# Altium schematic sheet 01_FPGA_FlashMem.SchDoc
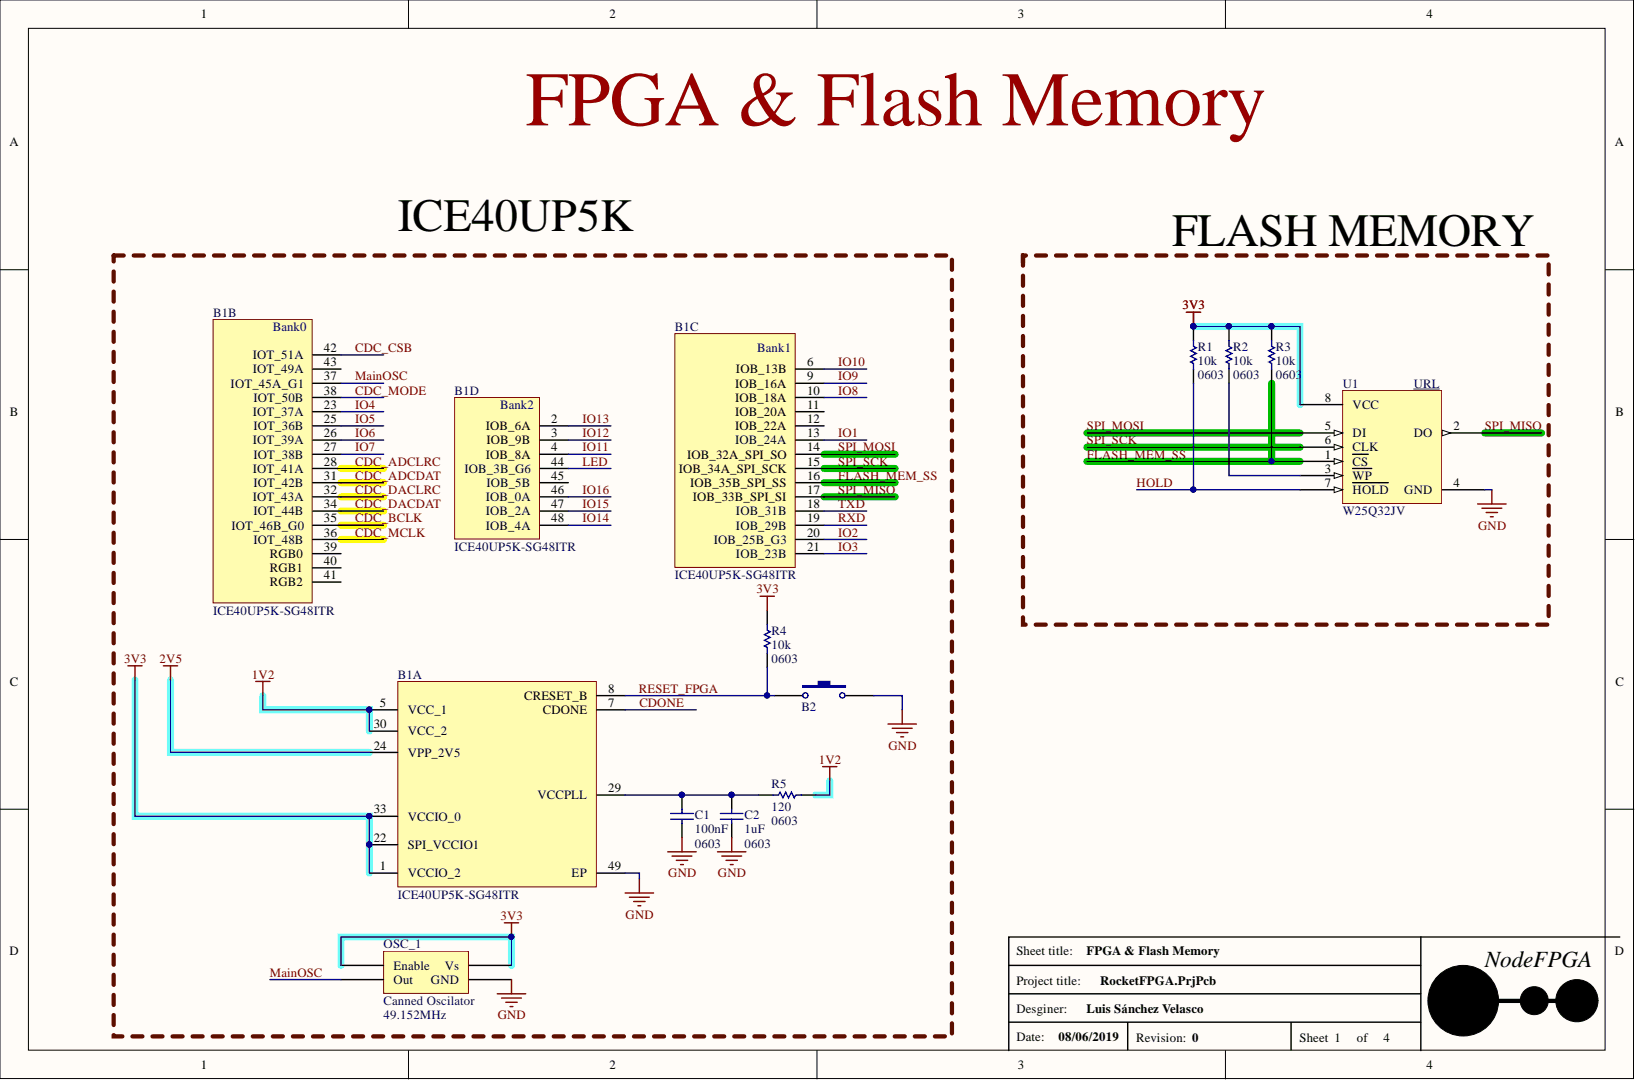
[diagram p0: 01_FPGA_FlashMem.SchDoc]

## Parts
B1  ICE40UP5K-SG48ITR  [QFN50P700X700X100-49N-D]  (parts A,B,C,D)
B2  FSM6JH  [SMD_PUSHBUTTON_3x6x2mm5]  (value not drawn)
C1  value 100nF  Package: 0603  [0603 CAP]  (hidden comment: Ceramic capacitor)
C2  value 1uF  Package: 0603  [0603 CAP]  (hidden comment: Ceramic capacitor)
OSC_1  Canned Oscilator  value 49.152MHz  [Canned Oscilator]
R1  value 10k  Package: 0603  [Resistencia 0603]  (hidden comment: Resistor)
R2  value 10k  Package: 0603  [Resistencia 0603]  (hidden comment: Resistor)
R3  value 10k  Package: 0603  [Resistencia 0603]  (hidden comment: Resistor)
R4  value 10k  Package: 0603  [Resistencia 0603]  (hidden comment: Resistor)
R5  value 120  Package: 0603  [Resistencia 0603]  (hidden comment: Resistor)
U1  W25Q32JV  [W25Q32J]

## Nets
1V2: B1.5(VCC_1) B1.30(VCC_2) R5.2
2V5: B1.24(VPP_2V5)
3V3: B1.1(VCCIO_2) B1.22(SPI_VCCIO1) B1.33(VCCIO_0) OSC_1.1(Enable) OSC_1.4(Vs) R1.2 R2.2 R3.2 R4.2 U1.8(VCC)
GND: B1.49(EP) B2.2 C1.1 C2.1 OSC_1.2(GND) U1.4(GND)
CDC_ADCDAT: B1.31(IOT_42B)
CDC_ADCLRC: B1.28(IOT_41A)
CDC_BCLK: B1.35(IOT_46B_G0)
CDC_CSB: B1.42(IOT_51A)
CDC_DACDAT: B1.34(IOT_44B)
CDC_DACLRC: B1.32(IOT_43A)
CDC_MCLK: B1.36(IOT_48B)
CDC_MODE: B1.38(IOT_50B)
CDONE: B1.7(CDONE)
FLASH_MEM_SS: B1.16(IOB_35B_SPI_SS) R3.1 U1.1(CS)
HOLD: R1.1 U1.7(HOLD)
IO1: B1.13(IOB_24A)
IO2: B1.20(IOB_25B_G3)
IO3: B1.21(IOB_23B)
IO4: B1.23(IOT_37A)
IO5: B1.25(IOT_36B)
IO6: B1.26(IOT_39A)
IO7: B1.27(IOT_38B)
IO8: B1.10(IOB_18A)
IO9: B1.9(IOB_16A)
IO10: B1.6(IOB_13B)
IO11: B1.4(IOB_8A)
IO12: B1.3(IOB_9B)
IO13: B1.2(IOB_6A)
IO14: B1.48(IOB_4A)
IO15: B1.47(IOB_2A)
IO16: B1.46(IOB_0A)
LED: B1.44(IOB_3B_G6)
MainOSC: B1.37(IOT_45A_G1) OSC_1.3(Out)
RESET_FPGA: B1.8(CRESET_B) B2.1 R4.1
RXD: B1.19(IOB_29B)
SPI_MISO: B1.17(IOB_33B_SPI_SI) U1.2(DO)
SPI_MOSI: B1.14(IOB_32A_SPI_SO) U1.5(DI)
SPI_SCK: B1.15(IOB_34A_SPI_SCK) U1.6(CLK)
TXD: B1.18(IOB_31B)
NetB1_29: B1.29(VCCPLL) C1.2 C2.2 R5.1
NetR2_1: R2.1 U1.3(WP)

Not connected: B1 11(IOB_20A) 12(IOB_22A) 39(RGB0) 40(RGB1) 41(RGB2) 43(IOT_49A) 45(IOB_5B)

## Library data of the parts
B1 = ICE40UP5K-SG48ITR: FPGA - Field Programmable Gate Array iCE40-UltraPlus, 5280 LUTs, 1.2V; Manufacturer_Name: Lattice; Geometry.Height: 1mm; vendor: Mouser; vendorID: 842-ICE40UP5K-SG48I; Component Kind: Standard; Datasheet Link: https://componentsearchengine.com/Datasheets/1/ICE40UP5K-SG48ITR.pdf; Library Name: NodeFPGA.SCHLIB; Manufacturer_Part_Number: ICE40UP5K-SG48ITR; Mouser Part Number: 842-40UP5KSG48ITR; price: 5.29
B2 = FSM6JH: Button THT Switch; vendor: Mouser; vendorID: 611-PTS636SL43SMTRLF; Component Kind: Standard; Library Name: NodeFPGA.SCHLIB; price: 0.096; Supplier 1: Mouser; Supplier Part Number 1: 506-FSM6JH
C1 = Capacitor: vendor: LCSC; vendorID: 0603F104M500NT; Component Kind: Standard; Description: Capacitor; Library Name: NodeFPGA.SCHLIB; ManufacturerReference: -; price: 0.0023; Signal Integrity: Cap; Simulation: CAP; Supplier 1: Mouser; Supplier Part Number 1: 80-C1206C104K5R
C2 = Capacitor: vendor: LCSC; vendorID: CC0603ZRY5V7BB105; Component Kind: Standard; Description: Capacitor; Library Name: NodeFPGA.SCHLIB; ManufacturerReference: -; price: 0.0053; Signal Integrity: Cap; Simulation: CAP; Supplier 1: Mouser; Supplier Part Number 1: 80-C1206C104K5R
OSC_1 = Canned Oscilator: Canned Oscilator -LFSPXO019116BULK; ManualRef: 449-LFSPXO019116BULK; vendor: Mouser; vendorID: 774-CB3LV-3C-49M1; Component Kind: Standard; Library Name: NodeFPGA.SCHLIB; price: 1.18
R1-R4 = Resistor: vendor: LCSC; vendorID: 0603WAF1002T5E; Component Kind: Standard; Description: Resistor; Library Name: NodeFPGA.SCHLIB; ManufacturerReference: -; price: 0.0009; Signal Integrity: Res; Simulation: RESISTOR; Supplier 1: Farnell; Supplier Part Number 1: 2358436
R5 = Resistor: vendor: LCSC; vendor2: vendor; vendorID: CR0603FA1200G; Component Kind: Standard; Description: Resistor; Library Name: NodeFPGA.SCHLIB; ManufacturerReference: -; price: 0.0024; Signal Integrity: Res; Simulation: RESISTOR; Supplier 1: Farnell; Supplier Part Number 1: 2358436
U1 = W25Q32JV: 32M-BIT SERIAL FLASH MEMORY WITH DUAL AND QUAD SPI; vendor: Mouser; vendorID: 454-W25Q32JVSSIQ; Component Kind: Standard; Library Name: NodeFPGA.SCHLIB; ManufacturerReference: W25Q32J; Package: SOIC-8; price: 0.603
